# Altium schematic sheet Power Selection - Power Path.SchDoc
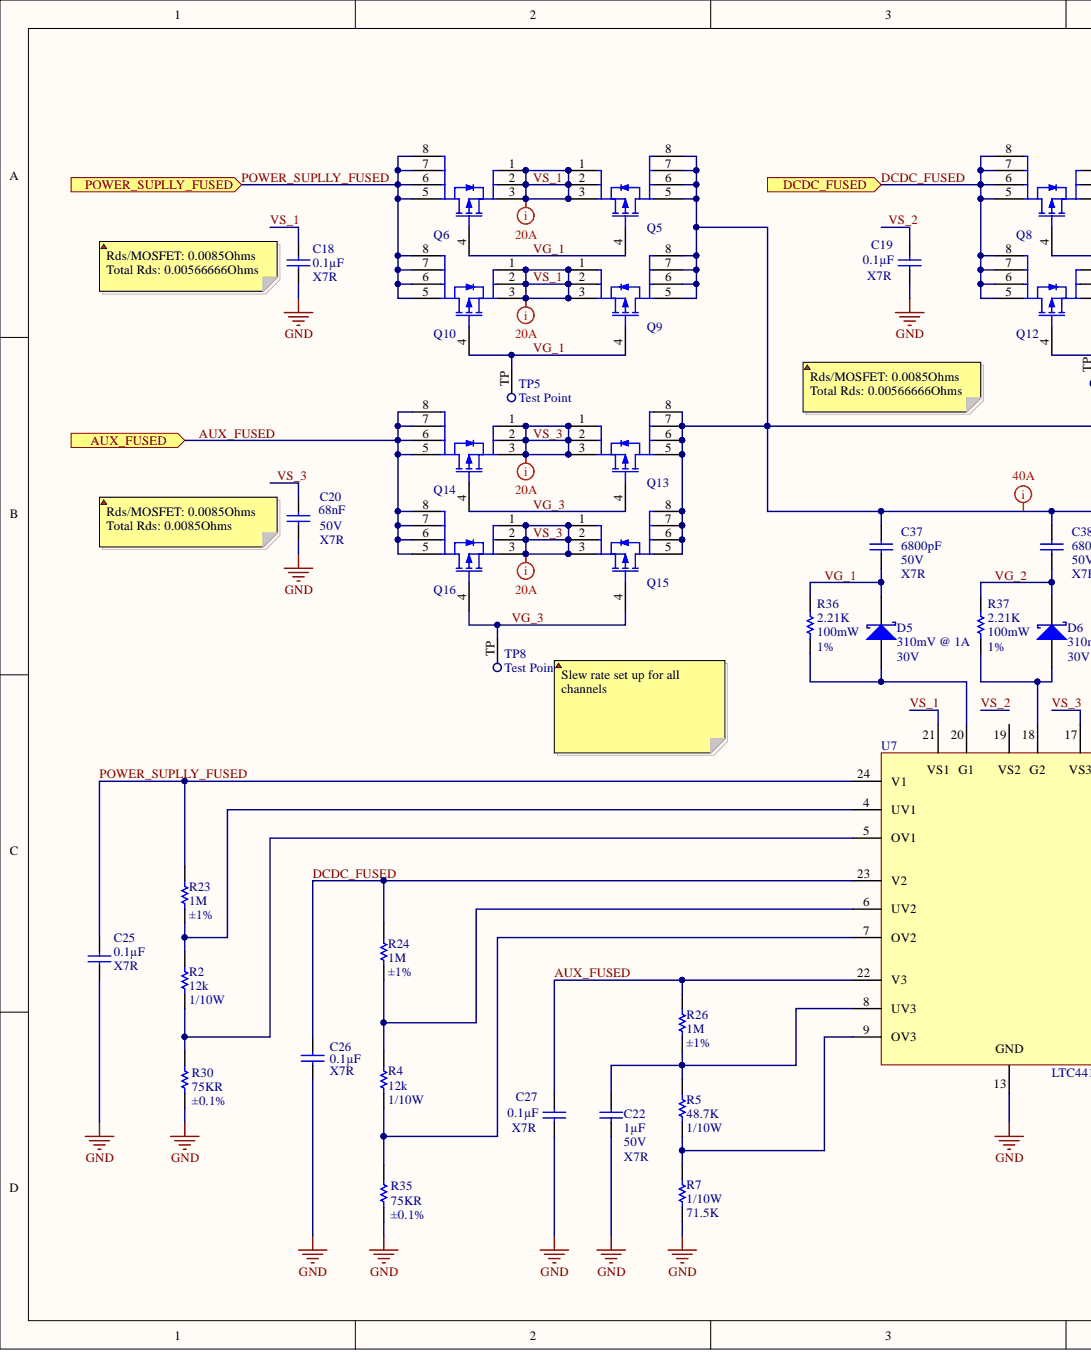
[diagram p0: Power Selection - Power Path.SchDoc, left]
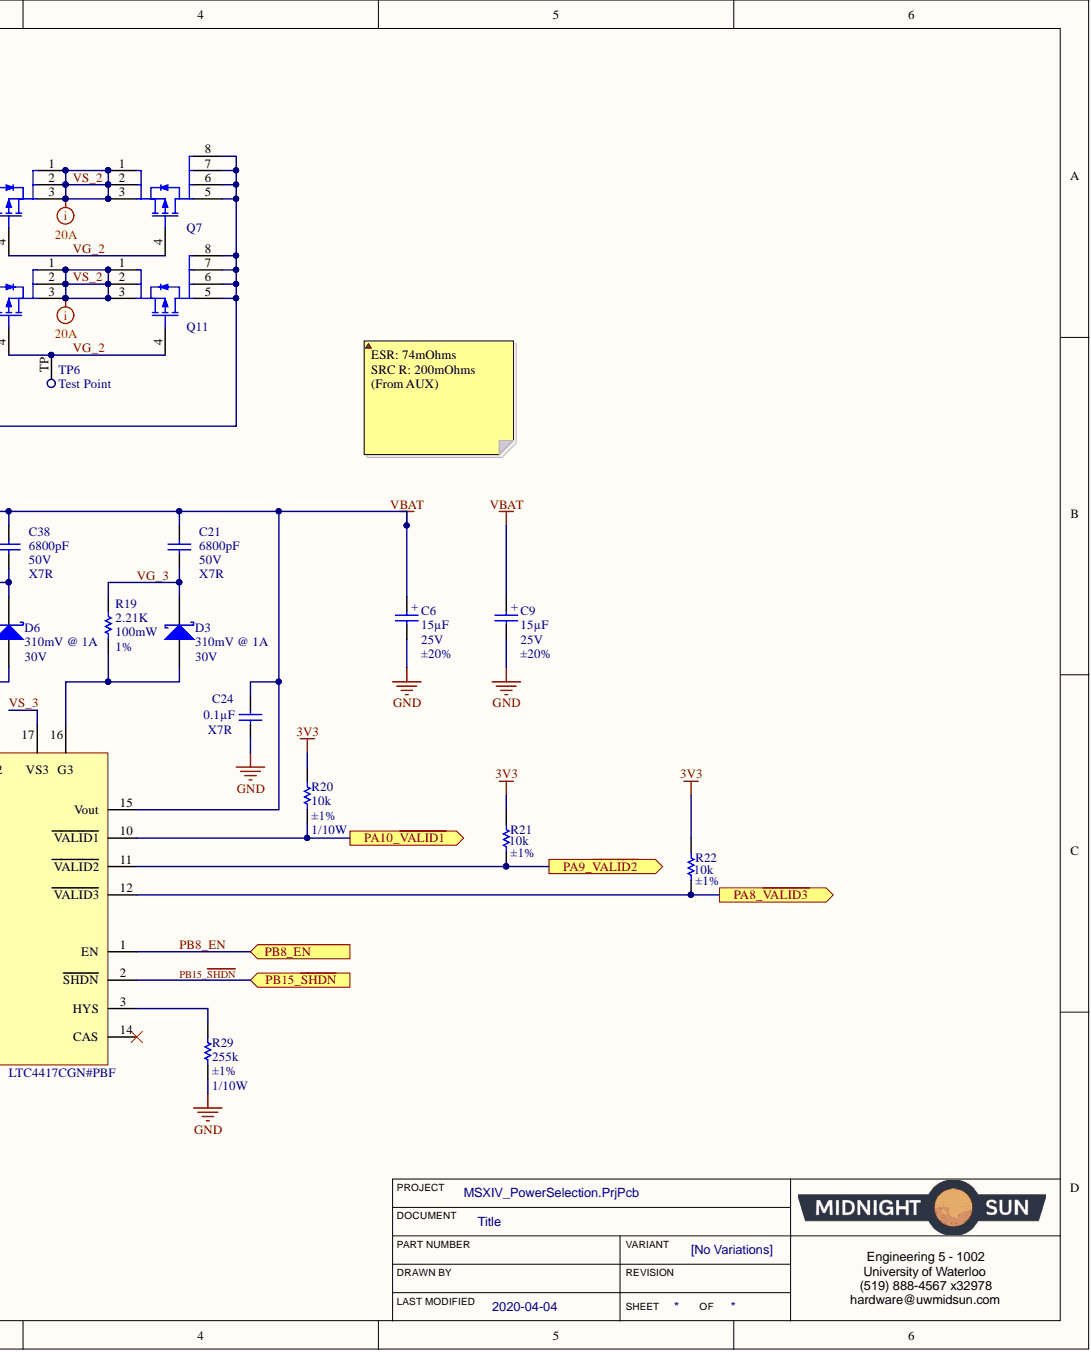
[diagram p1: Power Selection - Power Path.SchDoc, right]

## Parts
C6  value 15µF  Tolerance: ±20%  Voltage: 25V  [CAP ALUM 5.0MM RADIAL SMD]  (hidden comment: CAP ALUM 15UF 20% 25V SMD)
C9  value 15µF  Tolerance: ±20%  Voltage: 25V  [CAP ALUM 5.0MM RADIAL SMD]  (hidden comment: CAP ALUM 15UF 20% 25V SMD)
C18  Capacitance: 0.1µF  Temperature Coefficient: X7R  [CAP, 0603]  (hidden comment: CAP CER 0.1UF 50V 10% X7R 0603)
C19  Capacitance: 0.1µF  Temperature Coefficient: X7R  [CAP, 0603]  (hidden comment: CAP CER 0.1UF 50V 10% X7R 0603)
C20  68nF  Dielectric: X7R  Voltage Rating: 50V  [CAP, 0603]
C21  Capacitance: 6800pF  Temperature Coefficient: X7R  Voltage: 50V  [CAP, 0603]  (hidden comment: CAP CER 6800pF 50V 10% X7R 0603)
C22  Capacitance: 1µF  Temperature Coefficient: X7R  Voltage: 50V  [CAP, 0603]  (hidden comment: CAP CER 1UF 50V 10% X7R 0603)
C24  Capacitance: 0.1µF  Temperature Coefficient: X7R  [CAP, 0603]  (hidden comment: CAP CER 0.1UF 50V 10% X7R 0603)
C25  Capacitance: 0.1µF  Temperature Coefficient: X7R  [CAP, 0603]  (hidden comment: CAP CER 0.1UF 50V 10% X7R 0603)
C26  Capacitance: 0.1µF  Temperature Coefficient: X7R  [CAP, 0603]  (hidden comment: CAP CER 0.1UF 50V 10% X7R 0603)
C27  Capacitance: 0.1µF  Temperature Coefficient: X7R  [CAP, 0603]  (hidden comment: CAP CER 0.1UF 50V 10% X7R 0603)
C37  Capacitance: 6800pF  Temperature Coefficient: X7R  Voltage: 50V  [CAP, 0603]  (hidden comment: CAP CER 6800pF 50V 10% X7R 0603)
C38  Capacitance: 6800pF  Temperature Coefficient: X7R  Voltage: 50V  [CAP, 0603]  (hidden comment: CAP CER 6800pF 50V 10% X7R 0603)
D3  Voltage - DC Reverse (Vr) (Max): 30V  [DIODE SCHOTTKY 30V 1A POWERDI123]  (hidden comment: DIODE SCHOTTKY 30V 1A POWERDI123)
D5  Voltage - DC Reverse (Vr) (Max): 30V  [DIODE SCHOTTKY 30V 1A POWERDI123]  (hidden comment: DIODE SCHOTTKY 30V 1A POWERDI123)
D6  Voltage - DC Reverse (Vr) (Max): 30V  [DIODE SCHOTTKY 30V 1A POWERDI123]  (hidden comment: DIODE SCHOTTKY 30V 1A POWERDI123)
Q5  MOSFET P-CH PWR56 40V 4.9 MOHM  [PMOS PWR56 40V 4.9 MOHM]  (value not drawn)
Q6  MOSFET P-CH PWR56 40V 4.9 MOHM  [PMOS PWR56 40V 4.9 MOHM]  (value not drawn)
Q7  MOSFET P-CH PWR56 40V 4.9 MOHM  [PMOS PWR56 40V 4.9 MOHM]  (value not drawn)
Q8  MOSFET P-CH PWR56 40V 4.9 MOHM  [PMOS PWR56 40V 4.9 MOHM]  (value not drawn)
Q9  MOSFET P-CH PWR56 40V 4.9 MOHM  [PMOS PWR56 40V 4.9 MOHM]  (value not drawn)
Q10  MOSFET P-CH PWR56 40V 4.9 MOHM  [PMOS PWR56 40V 4.9 MOHM]  (value not drawn)
Q11  MOSFET P-CH PWR56 40V 4.9 MOHM  [PMOS PWR56 40V 4.9 MOHM]  (value not drawn)
Q12  MOSFET P-CH PWR56 40V 4.9 MOHM  [PMOS PWR56 40V 4.9 MOHM]  (value not drawn)
Q13  MOSFET P-CH PWR56 40V 4.9 MOHM  [PMOS PWR56 40V 4.9 MOHM]  (value not drawn)
Q14  MOSFET P-CH PWR56 40V 4.9 MOHM  [PMOS PWR56 40V 4.9 MOHM]  (value not drawn)
Q15  MOSFET P-CH PWR56 40V 4.9 MOHM  [PMOS PWR56 40V 4.9 MOHM]  (value not drawn)
Q16  MOSFET P-CH PWR56 40V 4.9 MOHM  [PMOS PWR56 40V 4.9 MOHM]  (value not drawn)
R2  value 12k  Power: 1/10W  [RES, 0603]  (hidden comment: RES 12K OHM 1% 1/10W 0603)
R4  value 12k  Power: 1/10W  [RES, 0603]  (hidden comment: RES 12K OHM 1% 1/10W 0603)
R5  value 48.7K  Power (Watts): 1/10W  [RES, 0603]  (hidden comment: RES SMD 48.7K OHM 1% 1/10W 0603)
R7  value 71.5K  Power (Watts): 1/10W  [RES, 0603]  (hidden comment: RES 71.5K OHM 1% 1/10W 0603)
R19  2.21K  Max Power Dissipation: 100mW  Tolerance: 1%  [RES, 0603]
R20  Power: 1/10W  Resistance: 10k  Tolerance: ±1%  [RES, 0603]  (hidden comment: RES 10K OHM 1% 1/10W 0603)
R21  Resistance: 10k  Tolerance: ±1%  [RES, 0603]  (hidden comment: RES 10K OHM 1% 1/10W 0603)
R22  Resistance: 10k  Tolerance: ±1%  [RES, 0603]  (hidden comment: RES 10K OHM 1% 1/10W 0603)
R23  Resistance (Ohms): 1M  Tolerance: ±1%  [RES, 0603]  (hidden comment: RES 1M OHM 1% 1/10W 0603)
R24  Resistance (Ohms): 1M  Tolerance: ±1%  [RES, 0603]  (hidden comment: RES 1M OHM 1% 1/10W 0603)
R26  Resistance (Ohms): 1M  Tolerance: ±1%  [RES, 0603]  (hidden comment: RES 1M OHM 1% 1/10W 0603)
R29  Power (Watts): 1/10W  Resistance (Ohms): 255k  Tolerance: ±1%  [RES, 0603]  (hidden comment: RES 255K OHM 1% 1/10W 0603)
R30  value 75KR  Tolerance: ±0.1%  [RES, 0603]  (hidden comment: RES SMD 75K OHM 0.1% 1/10W 0603)
R35  value 75KR  Tolerance: ±0.1%  [RES, 0603]  (hidden comment: RES SMD 75K OHM 0.1% 1/10W 0603)
R36  2.21K  Max Power Dissipation: 100mW  Tolerance: 1%  [RES, 0603]
R37  2.21K  Max Power Dissipation: 100mW  Tolerance: 1%  [RES, 0603]
TP5  Test Point  [Test Point]  (no BOM)
TP6  Test Point  [Test Point]  (no BOM)
TP8  Test Point  [Test Point]  (no BOM)
U7  Manufacturer Part Number 1: LTC4417CGN#PBF  [IC OR CTRLR SRC SELECT 24SSOP]  (hidden comment: IC OR CONTROLLER SOURCE SELECT 24SSOP)

## Ports
AUX_FUSED, DCDC_FUSED, PA8_~{VALID3}, PA9_~{VALID2}, PA10_~{VALID1}, PB8_EN, PB15_~{SHDN}, POWER_SUPLLY_FUSED

## Nets
3V3: R20.1 R21.1 R22.1
GND: C6.2 C9.2 C18.2 C19.2 C20.2 C22.2 C24.2 C25.2 C26.2 C27.2 R7.2 R29.2 R30.2 R35.2 U7.13
VBAT: C6.1 C9.1 C21.1 C24.1 C37.1 C38.1 Q5.5 Q5.6 Q5.7 Q5.8 Q7.5 Q7.6 Q7.7 Q7.8 Q9.5 Q9.6 Q9.7 Q9.8 Q11.5 Q11.6 Q11.7 Q11.8 Q13.5 Q13.6 Q13.7 Q13.8 Q15.5 Q15.6 Q15.7 Q15.8 U7.15(Vout)
AUX_FUSED: C27.1 Q14.5 Q14.6 Q14.7 Q14.8 Q16.5 Q16.6 Q16.7 Q16.8 R26.1 U7.22(V3) ; port AUX_FUSED
DCDC_FUSED: C26.1 Q8.5 Q8.6 Q8.7 Q8.8 Q12.5 Q12.6 Q12.7 Q12.8 R24.1 U7.23(V2) ; port DCDC_FUSED
PA8_~{VALID3}: R22.2 U7.12(VALID3) ; port PA8_~{VALID3}
PA9_~{VALID2}: R21.2 U7.11(VALID2) ; port PA9_~{VALID2}
PA10_~{VALID1}: R20.2 U7.10(VALID1) ; port PA10_~{VALID1}
PB8_EN: U7.1(EN) ; port PB8_EN
PB15_~{SHDN}: U7.2(SHDN) ; port PB15_~{SHDN}
POWER_SUPLLY_FUSED: C25.1 Q6.5 Q6.6 Q6.7 Q6.8 Q10.5 Q10.6 Q10.7 Q10.8 R23.1 U7.24(V1) ; port POWER_SUPLLY_FUSED
VG_1: C37.2 D5.2 Q5.4 Q6.4 Q9.4 Q10.4 R36.1 TP5.TP
VG_2: C38.2 D6.2 Q7.4 Q8.4 Q11.4 Q12.4 R37.1 TP6.TP
VG_3: C21.2 D3.2 Q13.4 Q14.4 Q15.4 Q16.4 R19.1 TP8.TP
VS_1: C18.1 Q5.1 Q5.2 Q5.3 Q6.1 Q6.2 Q6.3 Q9.1 Q9.2 Q9.3 Q10.1 Q10.2 Q10.3 U7.21
VS_2: C19.1 Q7.1 Q7.2 Q7.3 Q8.1 Q8.2 Q8.3 Q11.1 Q11.2 Q11.3 Q12.1 Q12.2 Q12.3
VS_3: C20.1 Q13.1 Q13.2 Q13.3 Q14.1 Q14.2 Q14.3 Q15.1 Q15.2 Q15.3 Q16.1 Q16.2 Q16.3 U7.17
NetC22_1: C22.1 R5.1 R26.2 U7.8(UV3)
NetD3_1: D3.1 R19.2 U7.16(G3)
NetD5_1: D5.1 R36.2 U7.20(G1)
NetD6_1: D6.1 R37.2 U7.18(G2)
NetR2_1: R2.1 R23.2 U7.4(UV1)
NetR2_2: R2.2 R30.1 U7.5(OV1)
NetR4_1: R4.1 R24.2 U7.6(UV2)
NetR4_2: R4.2 R35.1 U7.7(OV2)
NetR5_2: R5.2 R7.1 U7.9(OV3)
NetR29_1: R29.1 U7.3(HYS)

Not connected: U7 14(CAS) 19

## Library data of the parts
C6 C9 = CAP ALUM 15UF 20% 25V SMD: 15µF 25V Aluminum Electrolytic Capacitors Radial, Can - SMD 2000 Hrs @ 105°C; Component Kind: Standard; ComponentLink1Description: https://www.nichicon.co.jp/english/products/pdfs/e-ucd.pdf; ComponentLink1URL: https://www.nichicon.co.jp/english/products/pdfs/e-ucd.pdf; Supplier 1: Digi-Key; Supplier Part Number 1: 493-14922-1-ND; Library Name: Schematic Diagrams.SchLib
C18 C19 C24-C27 = CAP CER 0.1UF 50V 10% X7R 0603: 0.10µF ±10% 50V Ceramic Capacitor X7R 0603 (1608 Metric); Manufacturer 1: AVX Corporation; Manufacturer Part Number 1: 06035C104KAT2A; Component Kind: Standard; ComponentLink1Description: http://datasheets.avx.com/X7RDielectric.pdf; ComponentLink1URL: http://datasheets.avx.com/X7RDielectric.pdf; Height - Seated (Max): -; Lead Spacing: -; Lead Style: -; Mounting Type: Surface Mount, MLCC; Operating Temperature: -55°C ~ 125°C; Package / Case: 0603 (1608 Metric); Packaging: Cut Tape (CT); Part Status: Active; Ratings: -; Size / Dimension: 0.063" L x 0.032" W (1.60mm x 0.81mm); Supplier 1: Digi-Key; Supplier Part Number 1: 478-5052-1-ND; Thickness (Max): 0.035" (0.90mm); Tolerance: ±10%; Voltage: 50V; Library Name: Schematic Diagrams.SchLib
C20 = CAP CER 0.068UF 10% 50V X7R 0603: 0.068µF 50V Ceramic Capacitor X7R 0603 (1608 Metric) 0.063" L x 0.032" W (1.60mm x 0.80mm); Manufacturer: Murata; Manufacturer Part Number: GCM188R71H683KA57D; Tolerance: 10%; Capacitance: 68nF; Case/Package: 0603; Case Code (Imperial): 0603; Case Code (Metric): 1608; Component Kind: Standard; ComponentLink1Description: https://datasheet.ciiva.com/29542/s0717e-29542427.pdf; ComponentLink1URL: https://datasheet.ciiva.com/29542/s0717e-29542427.pdf; ComponentLink2Description: https://datasheet.ciiva.com/29542/s0717e-29542428.pdf; ComponentLink2URL: https://datasheet.ciiva.com/29542/s0717e-29542428.pdf; ComponentLink3Description: https://datasheet.ciiva.com/29542/s0717e-29542429.pdf; ComponentLink3URL: https://datasheet.ciiva.com/29542/s0717e-29542429.pdf; Depth: 0.8mm; Height: 0.8mm; Length: 1.6mm; Max Operating Temperature: 125°C; Min Operating Temperature: -55°C; Mount: Surface Mount; Package Quantity: 4000; Packaging: Tape and Reel; Pricing 1: 1=0.16, 10=0.116, 100=0.0547, 500=0.03832, 1000=0.03172 (USD); Radiation Hardening: No; Stock 1: 40000; Supplier 1: Digi-Key; Supplier Part Number 1: 490-8027-1-ND; Termination: SMD/SMT; Thickness: 0.035inch; Voltage Rating (DC): 50V; Width: 0.031inch; Library Name: Schematic Diagrams.SchLib
C21 C37 C38 = CAP CER 6800pF 50V 10% X7R 0603: 6800pF ±10% 50V Ceramic Capacitor X7R 0603 (1608 Metric); Manufacturer 1: Samsung Electro-Mechanics America, Inc.; Manufacturer Part Number 1: CL10B682KB8SFNC; Component Kind: Standard; ComponentLink1Description: http://www.samsungsem.com/kr/support/product-search/mlcc/CL10B682KB8SFNC.jsp; ComponentLink1URL: http://www.samsungsem.com/kr/support/product-search/mlcc/CL10B682KB8SFNC.jsp; Height - Seated (Max): -; Lead Spacing: -; Lead Style: -; Mounting Type: Surface Mount, MLCC; Operating Temperature: -55°C ~ 125°C; Package / Case: 0603 (1608 Metric); Packaging: Cut Tape (CT); Part Status: Active; Ratings: -; Size / Dimension: 0.063" L x 0.031" W (1.60mm x 0.80mm); Supplier 1: Digi-Key; Supplier Part Number 1: 1276-2103-1-ND; Thickness (Max): 0.035" (0.90mm); Tolerance: ±10%; Library Name: Schematic Diagrams.SchLib
C22 = CAP CER 1UF 50V 10% X7R 0603: 1µF ±10% 50V Ceramic Capacitor X7R 0603 (1608 Metric); Manufacturer 1: Taiyo Yuden; Manufacturer Part Number 1: UMK107AB7105KA-T; Component Kind: Standard; ComponentLink1Description: http://www.yuden.co.jp/productdata/catalog/en/mlcc_all_e.pdf; ComponentLink1URL: http://www.yuden.co.jp/productdata/catalog/en/mlcc_all_e.pdf; Height - Seated (Max): -; Lead Spacing: -; Lead Style: -; Mounting Type: Surface Mount, MLCC; Operating Temperature: -55°C ~ 125°C; Package / Case: 0603 (1608 Metric); Packaging: Cut Tape (CT); Part Status: Active; Ratings: -; Size / Dimension: 0.063" L x 0.031" W (1.60mm x 0.80mm); Supplier 1: Digi-Key; Supplier Part Number 1: 587-3247-1-ND; Thickness (Max): 0.037" (0.95mm); Tolerance: ±10%; Library Name: Schematic Diagrams.SchLib
D3 D5 D6 = DIODE SCHOTTKY 30V 1A POWERDI123: Diode Schottky 30V 1A Surface Mount PowerDI™ 123; Manufacturer: Diodes Incorporated; Manufacturer Part Number: DFLS130L-7; Capacitance @ Vr, F: 76pF @ 10V, 1MHz; Current - Reverse Leakage @ Vr: 1mA @ 30V; Speed: Fast Recovery =< 500ns, > 200mA (Io); Voltage - Forward (Vf) (Max) @ If: 310mV @ 1A; Category: Discrete Semiconductor Products; Component Kind: Standard; ComponentLink1Description: https://www.diodes.com/assets/Datasheets/ds30492.pdf; ComponentLink1URL: https://www.diodes.com/assets/Datasheets/ds30492.pdf; Current - Average Rectified (Io): 1A; Diode Type: Schottky; Mounting Type: Surface Mount; Operating Temperature - Junction: -40°C ~ 125°C; Package / Case: POWERDI®123; Packaging: Cut Tape (CT); Part Status: Active; Pricing 1: 1=0.7, 10=0.599, 100=0.447, 500=0.35126, 1000=0.27142 (CAD); RoHS: RoHS Compliant; Stock 1: 68200; Supplier 1: Digi-Key; Supplier Device Package: PowerDI™ 123; Supplier Part Number 1: DFLS130LDICT-ND; Library Name: Schematic Diagrams.SchLib
Q5-Q16 = MOSFET P-CH PWR56 40V 4.9 MOHM: P-Channel 16V 2.5A (Ta) 650mW (Ta) Surface Mount X2-DFN2015-3; Current - Continuous Drain (Id) @ 25°C: 80A (Tc); Drive Voltage (Max Rds On,  Min Rds On): 4.5V, 10V; Gate Charge (Qg) (Max) @ Vgs: 107nC @ 10V; Input Capacitance (Ciss) (Max) @ Vds: 4840pF @ 20V; Power Dissipation (Max): 214W (Tj); Rds On (Max) @ Id, Vgs: 4.9mOhm @ 80A, 10V; Vgs(th) (Max) @ Id: 3V @ 250µA; Component Kind: Standard; ComponentLink1Description: https://www.onsemi.com/pub/Collateral/FDWS9508L-F085-D.PDF; ComponentLink1URL: https://www.onsemi.com/pub/Collateral/FDWS9508L-F085-D.PDF; Drain to Source Voltage (Vdss): 40V; FET Feature: -; FET Type: P-Channel; Mounting Type: Surface Mount; Operating Temperature: -55°C ~ 175°C (TJ); Package / Case: 8-PowerTDFN; Packaging: Cut Tape (CT); Part Status: Active; Supplier 1: Digi-Key; Supplier Device Package: FDWS9508L-F085OSCT-ND; Supplier Part Number 1: FDWS9508L-F085OSCT-ND; Technology: MOSFET (Metal Oxide); Vgs (Max): ±16V; Library Name: Schematic Diagrams.SchLib
R2 R4 = RES 12K OHM 1% 1/10W 0603: 12 kOhms ±1% 0.1W, 1/10W Chip Resistor 0603 (1608 Metric) Automotive AEC-Q200, Moisture Resistant Thick Film; Component Kind: Standard; ComponentLink1Description: https://www.te.com/commerce/DocumentDelivery/DDEController?Action=srchrtrv&DocNm=1773204-3&DocType=DS&DocLang=English; ComponentLink1URL: https://www.te.com/commerce/DocumentDelivery/DDEController?Action=srchrtrv&DocNm=1773204-3&DocType=DS&DocLang=English; Supplier 1: Digi-Key; Supplier Part Number 1: A129701CT-ND; Library Name: Schematic Diagrams.SchLib
R5 = RES SMD 48.7K OHM 1% 1/10W 0603: 48.7 kOhms ±1% 0.1W, 1/10W Chip Resistor 0603 (1608 Metric) Automotive AEC-Q200 Thick Film; Component Kind: Standard; ComponentLink1Description: https://industrial.panasonic.com/cdbs/www-data/pdf/RDA0000/AOA0000C304.pdf; ComponentLink1URL: https://industrial.panasonic.com/cdbs/www-data/pdf/RDA0000/AOA0000C304.pdf; Supplier 1: Digi-Key; Supplier Part Number 1: P48.7KHCT-ND; Library Name: Schematic Diagrams.SchLib
R7 = RES 71.5K OHM 1% 1/10W 0603: 71.5 kOhms ±1% 0.1W, 1/10W Chip Resistor 0603 (1608 Metric) Automotive AEC-Q200 Thick Film; Component Kind: Standard; ComponentLink1Description: https://www.seielect.com/catalog/sei-rmcf_rmcp.pdf; ComponentLink1URL: https://www.seielect.com/catalog/sei-rmcf_rmcp.pdf; Supplier 1: Digi-Key; Supplier Part Number 1: RMCF0603FT71K5CT-ND; Library Name: Schematic Diagrams.SchLib
R19 R36 R37 = RES 2.21K OHM 1% 1/10W 0603: 2.21 kOhms ±1% 0.1W, 1/10W Chip Resistor 0603 (1608 Metric) Automotive AEC-Q200, Moisture Resistant Thick Film; Manufacturer: Yageo; Manufacturer Part Number: AC0603FR-072K21L; Resistance: 2.21kR; Temperature Coefficient: 100ppm/°C; Voltage Rating: 50V; Working Voltage: 75V; Case/Package: 0603; Case Code (Imperial): 0603; Case Code (Metric): 1608; Component Kind: Standard; ComponentLink1Description: https://datasheet.ciiva.com/12576/pyu-ac-51-rohs-l-2-12576458.pdf; ComponentLink1URL: https://datasheet.ciiva.com/12576/pyu-ac-51-rohs-l-2-12576458.pdf; Composition: Thick Film; Height: 0.022inch; Lead Free: Lead Free; Length: 0.063inch; Max Operating Temperature: 155°C; Min Operating Temperature: -55°C; Number of Terminations: 2; Packaging: Tape and Reel; Power Rating: 100mW; Pricing 1: 1=0.1, 10=0.029, 100=0.0117, 1000=0.00527, 2500=0.00458 (USD); Stock 1: 11020; Supplier 1: Digi-Key; Supplier Part Number 1: YAG3586CT-ND; Termination: SMD/SMT; Weight: 7.1E-05oz; Width: 0.8mm; Library Name: Schematic Diagrams.SchLib
R20-R22 = RES 10K OHM 1% 1/10W 0603: RES SMD 10K OHM 1% 1/10W 0603; Manufacturer 1: Yageo; Manufacturer Part Number 1: RC0603FR-0710KL; Temperature Coefficient: ±100ppm/°C; Component Kind: Standard; ComponentLink1Description: http://www.yageo.com/documents/recent/PYu-RC_Group_51_RoHS_L_7.pdf; ComponentLink1URL: http://www.yageo.com/documents/recent/PYu-RC_Group_51_RoHS_L_7.pdf; Composition: Thick Film; Failure Rate: -; Height - Seated (Max): 0.022" (0.55mm); Operating Temperature: -55°C ~ 155°C; Package / Case: 0603 (1608 Metric); Packaging: Cut Tape (CT); Part Status: Active; Size / Dimension: 0.063" L x 0.031" W (1.60mm x 0.80mm); Supplier 1: Digi-Key; Supplier Device Package: 0603; Supplier Part Number 1: 311-10.0KHRCT-ND; Library Name: Schematic Diagrams.SchLib
R23 R24 R26 = RES 1M OHM 1% 1/10W 0603: 1M Ohm ±1% 0.1W, 1/10W Chip Resistor 0603 (1608 Metric) Moisture Resistant Thick Film; Manufacturer 1: Yageo; Manufacturer Part Number 1: RC0603FR-071ML; Description 1: RES SMD 1M OHM 1% 1/10W 0603; Temperature Coefficient: ±100ppm/°C; Category: Resistors; Component Kind: Standard; ComponentLink1Description: http://www.yageo.com/documents/recent/PYu-RC_Group_51_RoHS_L_7.pdf; ComponentLink1URL: http://www.yageo.com/documents/recent/PYu-RC_Group_51_RoHS_L_7.pdf; Composition: Thick Film; Failure Rate: -; Features: Moisture Resistant; Height - Seated (Max): 0.022" (0.55mm); Operating Temperature: -55°C ~ 155°C; Package / Case: 0603 (1608 Metric); Packaging: Cut Tape (CT); Part Status: Active; Pricing 1: 1=0.16, 10=0.022, 100=0.0089, 1000=0.00402, 2500=0.00349 (CAD); RoHS: RoHS Compliant; Size / Dimension: 0.063" L x 0.031" W (1.60mm x 0.80mm); Stock 1: 2336711; Supplier 1: Digi-Key; Supplier Device Package: 0603; Supplier Part Number 1: 311-1.00MHRCT-ND; Library Name: Schematic Diagrams.SchLib
R29 = RES 255K OHM 1% 1/10W 0603: 255k Ohm ±1% 0.1W, 1/10W Chip Resistor 0603 (1608 Metric) Moisture Resistant Thick Film; Manufacturer 1: Yageo; Manufacturer Part Number 1: RC0603FR-07255KL; Description 1: RES SMD 255K OHM 1% 1/10W 0603; Temperature Coefficient: ±100ppm/°C; Category: Resistors; Component Kind: Standard; ComponentLink1Description: http://www.yageo.com/documents/recent/PYu-RC_Group_51_RoHS_L_7.pdf; ComponentLink1URL: http://www.yageo.com/documents/recent/PYu-RC_Group_51_RoHS_L_7.pdf; Composition: Thick Film; Failure Rate: -; Features: Moisture Resistant; Height - Seated (Max): 0.022" (0.55mm); Operating Temperature: -55°C ~ 155°C; Package / Case: 0603 (1608 Metric); Packaging: Cut Tape (CT); Part Status: Active; Pricing 1: 1=0.16, 10=0.022, 100=0.0089, 1000=0.00402, 2500=0.00349 (CAD); RoHS: RoHS Compliant; Size / Dimension: 0.063" L x 0.031" W (1.60mm x 0.80mm); Stock 1: 106564; Supplier 1: Digi-Key; Supplier Device Package: 0603; Supplier Part Number 1: 311-255KHRCT-ND; Library Name: Schematic Diagrams.SchLib
R30 R35 = RES SMD 75K OHM 0.1% 1/10W 0603: 75 kOhms ±0.1% 0.1W, 1/10W Chip Resistor 0603 (1608 Metric) Automotive AEC-Q200 Thin Film; Manufacturer: Panasonic Electronic Components; Manufacturer Part Number: AC0603FR-0775RL; Temperature Coefficient: ±25ppm/°C; Category: Resistors; Component Kind: Standard; ComponentLink1Description: http://www.yageo.com/NewPortal/yageodocoutput?fileName=/pdf/R-Chip/PYu-AC_51_RoHS_L_6.pdf; ComponentLink1URL: http://www.yageo.com/NewPortal/yageodocoutput?fileName=/pdf/R-Chip/PYu-AC_51_RoHS_L_6.pdf; Composition: Thin Film; Failure Rate: -; Features: Automotive AEC-Q200; Height - Seated (Max): 0.022" (0.55mm); Operating Temperature: -55°C ~ 155°C; Package / Case: 0603 (1608 Metric); Packaging: Cut Tape (CT); Part Status: Active; Power (Watts): 0.1W, 1/10W; RoHS: RoHS Compliant; Size / Dimension: 0.063" L x 0.031" W (1.60mm x 0.80mm); Supplier 1: Digi-Key; Supplier Device Package: 0603; Supplier Part Number 1: P75KDBCT-ND; Library Name: Schematic Diagrams.SchLib
U7 = IC OR CONTROLLER SOURCE SELECT 24SSOP: OR Controller Source Selector Switch P-Channel 3:1 24-SSOP; Manufacturer 1: Linear Technology; Current - Supply: 28µA; Internal Switch(s): No; Voltage - Supply: 2.5 V ~ 36 V; Component Kind: Standard; ComponentLink1Description: http://www.linear.com/docs/42408; ComponentLink1URL: http://www.linear.com/docs/42408; Current - Output (Max): -; FET Type: P-Channel; Mounting Type: Surface Mount; Operating Temperature: 0°C ~ 70°C; Package / Case: 24-SSOP (0.154", 3.90mm Width); Packaging: Tube; Part Status: Active; Ratio - Input: 3:1; Supplier 1: Digi-Key; Supplier Device Package: 24-SSOP; Supplier Part Number 1: LTC4417CGN#PBF-ND; Type: Source Selector Switch; Library Name: Schematic Diagrams.SchLib
TP5 TP6 TP8 = Test Point: Library Name: Schematic Diagrams.SchLib
